# Altium schematic sheet LPG_Weighning_Scale.SchDoc
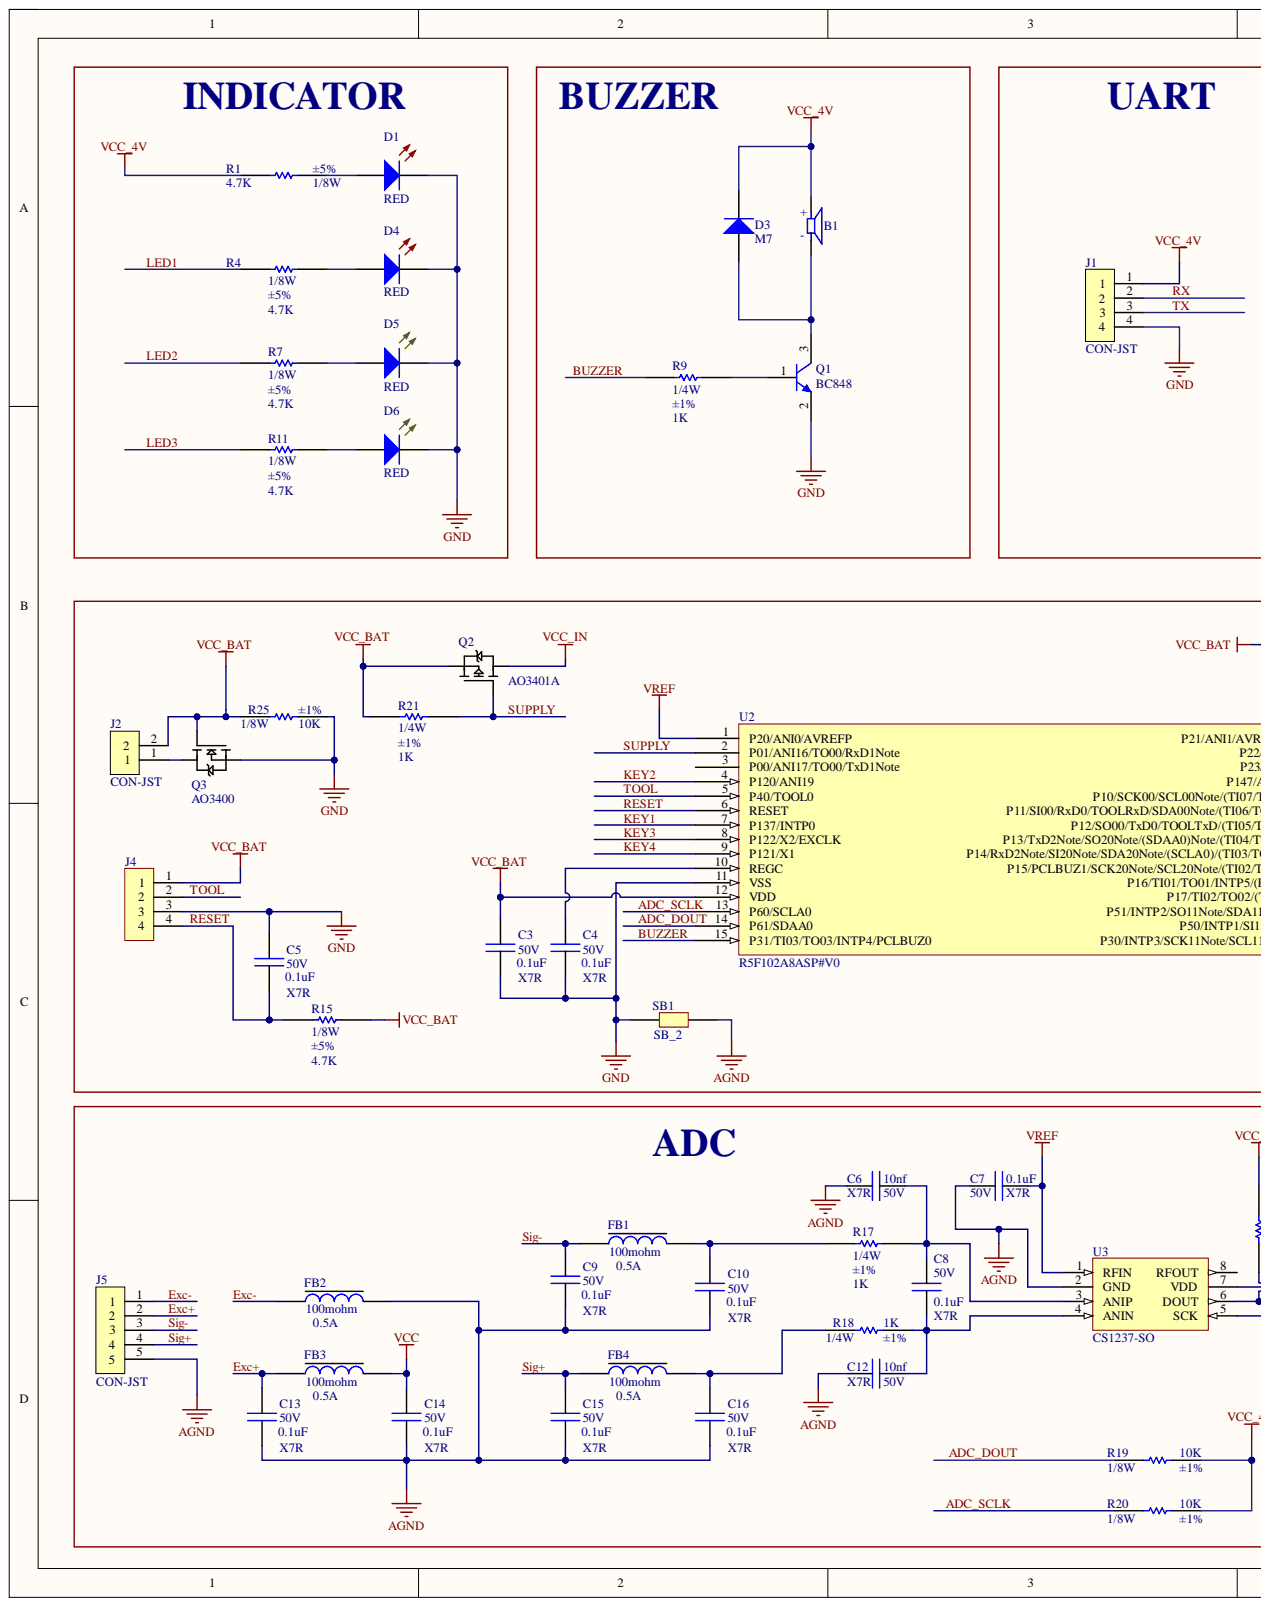
[diagram p0: LPG_Weighning_Scale.SchDoc, left]
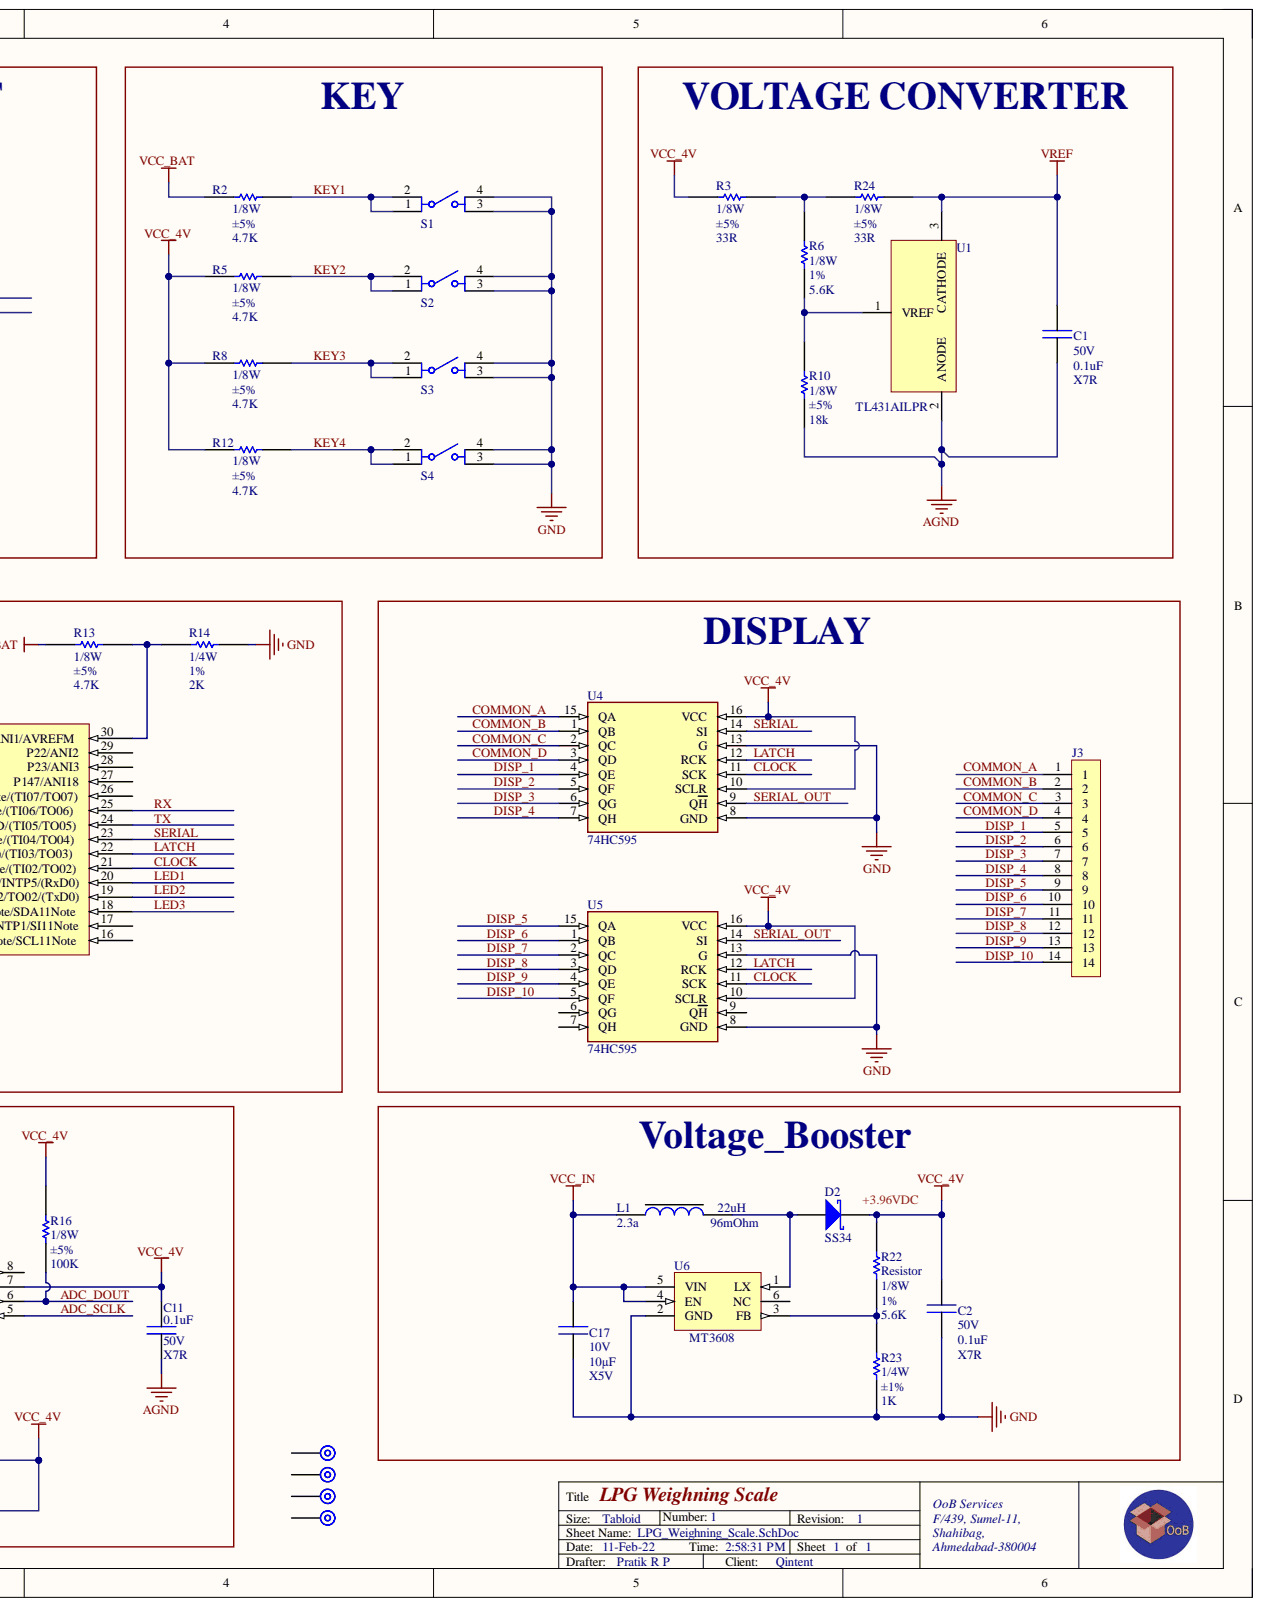
[diagram p1: LPG_Weighning_Scale.SchDoc, right]

## Parts
B1  BUZZER  [Buzzer_PT-1520PQ]  (value not drawn)
C1  value 0.1uF  Dielectric: X7R  Voltage: 50V  [CAPC2012X13_(0805)]  (hidden comment: Capacitor)
C2  value 0.1uF  Dielectric: X7R  Voltage: 50V  [CAPC2012X13_(0805)]  (hidden comment: Capacitor)
C3  value 0.1uF  Dielectric: X7R  Voltage: 50V  [CAPC2012X13_(0805)]  (hidden comment: Capacitor)
C4  value 0.1uF  Dielectric: X7R  Voltage: 50V  [CAPC2012X13_(0805)]  (hidden comment: Capacitor)
C5  value 0.1uF  Dielectric: X7R  Voltage: 50V  [CAPC2012X13_(0805)]  (hidden comment: Capacitor)
C6  value 10nf  Dielectric: X7R  Voltage: 50V  [CAPC2012X13_(0805)]  (hidden comment: Capacitor)
C7  value 0.1uF  Dielectric: X7R  Voltage: 50V  [CAPC2012X13_(0805)]  (hidden comment: Capacitor)
C8  value 0.1uF  Dielectric: X7R  Voltage: 50V  [CAPC2012X13_(0805)]  (hidden comment: Capacitor)
C9  value 0.1uF  Dielectric: X7R  Voltage: 50V  [CAPC2012X13_(0805)]  (hidden comment: Capacitor)
C10  value 0.1uF  Dielectric: X7R  Voltage: 50V  [CAPC2012X13_(0805)]  (hidden comment: Capacitor)
C11  value 0.1uF  Dielectric: X7R  Voltage: 50V  [CAPC2012X13_(0805)]  (hidden comment: Capacitor)
C12  value 10nf  Dielectric: X7R  Voltage: 50V  [CAPC2012X13_(0805)]  (hidden comment: Capacitor)
C13  value 0.1uF  Dielectric: X7R  Voltage: 50V  [CAPC2012X13_(0805)]  (hidden comment: Capacitor)
C14  value 0.1uF  Dielectric: X7R  Voltage: 50V  [CAPC2012X13_(0805)]  (hidden comment: Capacitor)
C15  value 0.1uF  Dielectric: X7R  Voltage: 50V  [CAPC2012X13_(0805)]  (hidden comment: Capacitor)
C16  value 0.1uF  Dielectric: X7R  Voltage: 50V  [CAPC2012X13_(0805)]  (hidden comment: Capacitor)
C17  value 10µF  Dielectric: X5V  Voltage: 10V  [CAPC2012X13_(0805)]  (hidden comment: Capacitor)
D1  RED  [Würth Elektronik_151031SS06000_LED3MM_ROUND]
D2  SS34  [SMA]
D3  M7  [SODFL530X110-2N]
D4  RED  [Würth Elektronik_151031SS06000_LED3MM_ROUND]
D5  RED  [Würth Elektronik_151031SS06000_LED3MM_ROUND]
D6  RED  [Würth Elektronik_151031SS06000_LED3MM_ROUND]
FB1  Current Rating: 0.5A  Resistance: 100mohm  [IND1680X70_(0603)]  (hidden comment: Ferrite Bead)
FB2  Current Rating: 0.5A  Resistance: 100mohm  [IND1680X70_(0603)]  (hidden comment: Ferrite Bead)
FB3  Current Rating: 0.5A  Resistance: 100mohm  [IND1680X70_(0603)]  (hidden comment: Ferrite Bead)
FB4  Current Rating: 0.5A  Resistance: 100mohm  [IND1680X70_(0603)]  (hidden comment: Ferrite Bead)
J1  CON-JST  [JST_S_4]
J2  CON-JST  [JST_S_2]
J3  CON-MH-2.54mm-14x1-Segment Display-Wurth  [MH_2.54mm_14_Dispay]  (value not drawn)
J4  61300411121  [MH_2.54mm_4_WURTH_61300411121]  (value not drawn)
J5  CON-JST  [JST_S_5]
L1  value 22uH  Amp: 2.3a  Resistor: 96mOhm  [SRN6045TA]  (hidden comment: SRR1208-120ML)
MH1  MT-d3_D3mm_5mmcopper-Mounting Hole-OOB_1  [MOUNTING_HOLE_3_5mm coper]  (value not drawn)
MH2  MT-d3_D3mm_5mmcopper-Mounting Hole-OOB_1  [MOUNTING_HOLE_3_5mm coper]  (value not drawn)
MH3  MT-d3_D3mm_5mmcopper-Mounting Hole-OOB_1  [MOUNTING_HOLE_3_5mm coper]  (value not drawn)
MH4  MT-d3_D3mm_5mmcopper-Mounting Hole-OOB_1  [MOUNTING_HOLE_3_5mm coper]  (value not drawn)
Q1  BC848  [SOT95P230X110-3N_(SOT23-3)]
Q2  MFG PN: AO3401A  [SOT95P230X110-3N_(SOT23-3)]  (hidden comment: Mosfet)
Q3  AO3400  [SOT95P230X110-3N_(SOT23-3)]
R1  Resistor  value 4.7K  Tolerance: ±5%  Wattage: 1/8W  [RESC2113X06N_(0805)]
R2  Resistor  value 4.7K  Tolerance: ±5%  Wattage: 1/8W  [RESC2113X06N_(0805)]
R3  Resistor  value 33R  Tolerance: ±5%  Wattage: 1/8W  [RESC2113X06N_(0805)]
R4  Resistor  value 4.7K  Tolerance: ±5%  Wattage: 1/8W  [RESC2113X06N_(0805)]
R5  Resistor  value 4.7K  Tolerance: ±5%  Wattage: 1/8W  [RESC2113X06N_(0805)]
R6  Resistor  value 5.6K  Tolerance: 1%  Wattage: 1/8W  [RESC2113X06N_(0805)]
R7  Resistor  value 4.7K  Tolerance: ±5%  Wattage: 1/8W  [RESC2113X06N_(0805)]
R8  Resistor  value 4.7K  Tolerance: ±5%  Wattage: 1/8W  [RESC2113X06N_(0805)]
R9  Resistor  value 1K  Tolerance: ±1%  Wattage: 1/4W  [RESC2113X06N_(0805)]
R10  Resistor  value 18k  Tolerance: ±5%  Wattage: 1/8W  [RESC2113X06N_(0805)]
R11  Resistor  value 4.7K  Tolerance: ±5%  Wattage: 1/8W  [RESC2113X06N_(0805)]
R12  Resistor  value 4.7K  Tolerance: ±5%  Wattage: 1/8W  [RESC2113X06N_(0805)]
R13  Resistor  value 4.7K  Tolerance: ±5%  Wattage: 1/8W  [RESC2113X06N_(0805)]
R14  Resistor  value 2K  Tolerance: 1%  Wattage: 1/4W  [RESC2113X06N_(0805)]
R15  Resistor  value 4.7K  Tolerance: ±5%  Wattage: 1/8W  [RESC2113X06N_(0805)]
R16  Resistor  value 100K  Tolerance: ±5%  Wattage: 1/8W  [RESC2113X06N_(0805)]
R17  Resistor  value 1K  Tolerance: ±1%  Wattage: 1/4W  [RESC2113X06N_(0805)]
R18  Resistor  value 1K  Tolerance: ±1%  Wattage: 1/4W  [RESC2113X06N_(0805)]
R19  Resistor  value 10K  Tolerance: ±1%  Wattage: 1/8W  [RESC2113X06N_(0805)]
R20  Resistor  value 10K  Tolerance: ±1%  Wattage: 1/8W  [RESC2113X06N_(0805)]
R21  Resistor  value 1K  Tolerance: ±1%  Wattage: 1/4W  [RESC2113X06N_(0805)]
R22  Resistor  value 5.6K  Tolerance: 1%  Wattage: 1/8W  [RESC2113X06N_(0805)]
R23  Resistor  value 1K  Tolerance: ±1%  Wattage: 1/4W  [RESC2113X06N_(0805)]
R24  Resistor  value 33R  Wattage: 1/8W  [RESC2113X06N_(0805)]
R25  Resistor  value 10K  Tolerance: ±1%  Wattage: 1/8W  [RESC2113X06N_(0805)]
S1  Switch  [DTE6]  (value not drawn)
S2  Switch  [DTE6]  (value not drawn)
S3  Switch  [DTE6]  (value not drawn)
S4  Switch  [DTE6]  (value not drawn)
SB1  SB_2  [SB_2]  (no BOM)
U1  TL431AILPR  [TO-92]
U2  R5F102A8ASP#V0  [TSOP65P810X130-30N]
U3  CS1237-SO  [SOIC127P600X175-8N]
U4  74HC595  [SOIC127P600X175-16N]
U5  74HC595  [SOIC127P600X175-16N]
U6  MFG PN: MT3608  [SOT23-6]  (hidden comment: IC-VREG-SOT23-6-AEROSEMI)

## Nets
AGND: C1.1 C6.2 C7.1 C9.2 C10.2 C11.1 C12.2 C13.2 C14.2 C15.2 C16.2 FB2.2 J5.5 R10.1 SB1.2 U1.2 U3.2(GND)
GND: C2.2 C3.2 C4.2 C5.1 C17.2 D1.C D4.C D5.C D6.C J1.4 J4.3 Q1.2 Q3.2 R14.1 R23.1 R25.2 S1.3 S1.4 S2.3 S2.4 S3.3 S3.4 S4.3 S4.4 SB1.1 U2.11(VSS) U4.8(GND) U4.13(G) U5.8(GND) U5.13(G) U6.2(GND)
VCC: C14.1 FB3.2
VCC_4V: B1.1 C2.1 C11.2 D2.2 D3.C J1.1 R1.1 R3.1 R5.1 R8.1 R12.1 R16.2 R19.1 R20.1 R22.2 U3.7(VDD) U4.10(SCLR) U4.16(VCC) U5.10(SCLR) U5.16(VCC)
VCC_BAT: C3.1 J2.2 J4.1 Q2.2 Q3.1 R2.1 R13.1 R15.2 R21.1 R25.1 U2.12(VDD)
VCC_IN: C17.1 L1.1 Q2.3 U6.4(EN) U6.5(VIN)
VREF: C1.2 C7.2 R24.2 U1.3 U2.1(P20/ANI0/AVREFP) U3.1(RFIN)
ADC_DOUT: R16.1 R19.2 U2.14(P61/SDAA0) U3.6(DOUT)
ADC_SCLK: R20.2 U2.13(P60/SCLA0) U3.5(SCK)
BUZZER: R9.1 U2.15(P31/TI03/TO03/INTP4/PCLBUZ0)
CLOCK: U2.21(P15/PCLBUZ1/SCK20Note/SCL20Note/(TI02/TO02)) U4.11(SCK) U5.11(SCK)
COMMON_A: J3.1 U4.15(QA)
COMMON_B: J3.2 U4.1(QB)
COMMON_C: J3.3 U4.2(QC)
COMMON_D: J3.4 U4.3(QD)
DISP_1: J3.5 U4.4(QE)
DISP_2: J3.6 U4.5(QF)
DISP_3: J3.7 U4.6(QG)
DISP_4: J3.8 U4.7(QH)
DISP_5: J3.9 U5.15(QA)
DISP_6: J3.10 U5.1(QB)
DISP_7: J3.11 U5.2(QC)
DISP_8: J3.12 U5.3(QD)
DISP_9: J3.13 U5.4(QE)
DISP_10: J3.14 U5.5(QF)
Exc+: C13.1 FB3.1 J5.2
Exc-: FB2.1 J5.1
KEY1: R2.2 S1.1 S1.2 U2.7(P137/INTP0)
KEY2: R5.2 S2.1 S2.2 U2.4(P120/ANI19)
KEY3: R8.2 S3.1 S3.2 U2.8(P122/X2/EXCLK)
KEY4: R12.2 S4.1 S4.2 U2.9(P121/X1)
LATCH: U2.22(P14/RxD2Note/SI20Note/SDA20Note/(SCLA0)/(TI03/TO03)) U4.12(RCK) U5.12(RCK)
LED1: R4.1 U2.20(P16/TI01/TO01/INTP5/(RxD0))
LED2: R7.1 U2.19(P17/TI02/TO02/(TxD0))
LED3: R11.1 U2.18(P51/INTP2/SO11Note/SDA11Note)
RESET: C5.2 J4.4 R15.1 U2.6(RESET)
RX: J1.2 U2.25(P11/SI00/RxD0/TOOLRxD/SDA00Note/(TI06/TO06))
SERIAL: U2.23(P13/TxD2Note/SO20Note/(SDAA0)Note/(TI04/TO04)) U4.14(SI)
SERIAL_OUT: U4.9(QH) U5.14(SI)
Sig+: C15.1 FB4.1 J5.4
Sig-: C9.1 FB1.1 J5.3
SUPPLY: Q2.1 R21.2 U2.2(P01/ANI16/TO00/RxD1Note)
TOOL: J4.2 U2.5(P40/TOOL0)
TX: J1.3 U2.24(P12/SO00/TxD0/TOOLTxD/(TI05/TO05))
NetB1_2: B1.2 D3.A Q1.3
NetC4_1: C4.1 U2.10(REGC)
NetC6_1: C6.1 C8.2 R17.2 U3.3(ANIP)
NetC8_1: C8.1 C12.1 R18.2 U3.4(ANIN)
NetC10_1: C10.1 FB1.2 R17.1
NetC16_1: C16.1 FB4.2 R18.1
NetD1_A: D1.A R1.2
NetD2_1: D2.1 L1.2 U6.1(LX)
NetD4_A: D4.A R4.2
NetD5_A: D5.A R7.2
NetD6_A: D6.A R11.2
NetJ2_1: J2.1 Q3.3
NetQ1_1: Q1.1 R9.2
NetR3_2: R3.2 R6.2 R24.1
NetR6_1: R6.1 R10.2 U1.1
NetR13_2: R13.2 R14.2 U2.30(P21/ANI1/AVREFM)
NetR22_1: R22.1 R23.2 U6.3(FB)

Not connected: MH1 MT; MH2 MT; MH3 MT; MH4 MT; U2 3(P00/ANI17/TO00/TxD1Note) 16(P30/INTP3/SCK11Note/SCL11Note) 17(P50/INTP1/SI11Note) 26(P10/SCK00/SCL00Note/(TI07/TO07)) 27(P147/ANI18) 28(P23/ANI3) 29(P22/ANI2); U3 8(RFOUT); U5 6(QG) 7(QH) 9(QH); U6 6(NC)

## Library data of the parts
B1 = BZ-PIEZO-TRANSDUCER-1-25V-TH-Mallory Sonalert Products Inc.: AUDIO PIEZO TRANSDUCER 1-25V TH; Lib Standard: 1.0; MFG PN: PT-1520PQ; Owner: OoB Services; Component Kind: Standard; Creation Date: 16-08-2021; Library Name: OoB_Integrated_Lib.IntLib; MFG: Mallory Sonalert Products Inc.; Engineer Created: SL
C1-C5 C7-C11 C13-C16 = CAP-0805-0.1uF-50V-X7R-CL-Samsung: CAP CER 0.1uF 50V X7R 0805; Component Status: Active; Lib Standard: 1.0; MFG: Samsung Electro-Mechanics; MFG PN: CL21B104KBCNNNC; MFG Series: CL; Owner: OoB Services; QA Status: Created; Component Kind: Standard; Creation Date: 11/1/2021; Library Name: OoB_Integrated_Lib.IntLib; Engineer Created: AC
C6 C12 = CAP-0805-10nf-50-C0G-1%-: CAP CER 0805 10NF 50V C0G 1%; Component Status: Active; Lib Standard: 1.0; MFG: KEMET; MFG PN: C0805C103F5GECAUTO; Owner: OoB Services; QA Status: Created; Component Kind: Standard; Creation Date: 17/05/2021; Library Name: OoB_Integrated_Lib.IntLib; Engineer Created: SL
C17 = CAP-0805-10µF-10V-X5V-YAGEO: CAP CER 10UF 10V Y5V 0805; Component Status: Active; Lib Standard: 1.0; MFG: YAGEO; MFG PN: CC0805ZKY5V6BB106; MFG Series: CL; Owner: OoB Services; QA Status: Created; Component Kind: Standard; Creation Date: 19/08/2021; Library Name: OoB_Integrated_Lib.IntLib; Engineer Created: SL
D1 D4 = D-LED-TH3MM-Würth Elektronik-WL-TMRC-RED: LED RED DIFFUSED 3MM ROUND T/H; Component Status: Active; Forward current: 20mA; Forward Voltage: 2.2V; Lib Standard: 1.0; MFG PN: 151031SS06000; Owner: OoB Services; QA Status: Created; Component Kind: Standard; Creation Date: 29/1/2021; Library Name: OoB_Integrated_Lib.IntLib; MFG: Würth Elektronik; MFG Series: WL-TMRC; Engineer Created: AC
D2 = D-30V-1A-SOD123-ON Semiconductor-SS34: DIODE SCHOTTKY 30V 500MA SOD123; Component Status: Active; Lib Standard: 1.0; MFG Series: D-FLAT; Owner: OoB Services; QA Status: Created; Component Kind: Standard; Creation Date: 10/03/2021; Forward current: 500MA; Library Name: OoB_Integrated_Lib.IntLib; MFG: ON Semiconductor; MFG PN: SS34; Reverse Voltage: 30V; Engineer Created: SL
D3 = D-STD-SMA-1000V-1A-Diodes Incorporated: DIODE STD SMA 1000V 1A; Component Status: Active; Forward Voltage: 1.7V; Lib Standard: 1.0; MFG Series: D-FLAT; Owner: OoB Services; QA Status: Created; Component Kind: Standard; Creation Date: 1/2/2021; Forward current: 1A; Library Name: OoB_Integrated_Lib.IntLib; MFG: Diodes Incorporated; MFG PN: M7; Reverse Voltage: 1000V; Engineer Created: AC
D5 D6 = D-LED-TH3MM-Kingbright-GREEN: LED GREEN DIFFUSED T-1 T/H; Component Status: Active; Forward current: 20mA; Forward Voltage: 2.2V; Lib Standard: 1.0; MFG PN: WP3A10GD; Owner: OoB Services; QA Status: Created; Component Kind: Standard; Creation Date: 6/8/21; Library Name: OoB_Integrated_Lib.IntLib; MFG: Kingbright; Engineer Created: AC
FB1-FB4 = FER-5A-100mohm-0603-Laird-Signal Integrity Products: FERRITE BEAD 47 OHM 0603 1LN; Component Status: Active; Impedance@100MHz: 47ohms; Lib Standard: 1.0; MFG PN: LI0603E470R-10; Owner: OoB Services; QA Status: Created; Component Kind: Standard; Creation Date: 14/08/2021; Library Name: OoB_Integrated_Lib.IntLib; MFG: Laird-Signal Integrity Products; Engineer Created: SL
J1 = CON-JST-2.5mm-4-XH-JST_Sales_America: CONN JST HEADER VERT 4POS 2.5MM; Component Status: In Dev/QA; Current Capacity: 3A; Lib Standard: 1.0; MFG: JST Sales America Inc.; MFG PN: B4B-XH-AM(LF)(SN); MFG Series: XH; Number of Positions: 4; Owner: OoB Sevices; QA Status: Created; Component Kind: Standard; Creation Date: 19/12/2020; Library Name: OoB_Integrated_Lib.IntLib; Number of Rows: 1; Pitch: 2.5mm; Engineer Created: PS
J2 = CON-JST-2.5mm-2-XH-JST_Sales_America: CONN JST HEADER VERT 2POS 2.5MM; Component Status: In Dev/QA; Current Capacity: 3A; Lib Standard: 1.0; MFG: JST Sales America Inc.; MFG PN: B2B-XH-AM(LF)(SN); MFG Series: XH; Number of Positions: 2; Owner: Oxit LLC; QA Status: Created; Component Kind: Standard; Creation Date: 19/12/2020; Library Name: OoB_Integrated_Lib.IntLib; Number of Rows: 1; Pitch: 2.5mm; Engineer Created: PS
J3 = CON-MH-2.54mm-14x1-Segment Display-Wurth: CONN HEADER VERT 14POS 2.54MM; Component Status: Active; Current Capacity: 3A; Lib Standard: 1.0; MFG: Würth Elektronik; MFG PN: 61301411121; Number of Positions: 14; Owner: OoB Services; QA Status: Created; Component Kind: Standard; Creation Date: 25/08/2021; Library Name: OoB_Integrated_Lib.IntLib; MFG Series: -; Number of Rows: 1; Pitch: 2.54mm; Engineer Created: SL
J4 = CON-MH-2.54mm-4x1-Wurth: CONN HEADER VERT 4POS 2.54MM; Component Status: Active; Current Capacity: 3A; Lib Standard: 1.0; MFG: Würth Elektronik; Number of Positions: 4; Owner: OoB Services; QA Status: Created; Component Kind: Standard; Creation Date: 22/12/2020; Library Name: OoB_Integrated_Lib.IntLib; MFG PN: 61300411121; MFG Series: -; Number of Rows: 1; Pitch: 2.54mm; Engineer Created: PP
J5 = CON-JST-2.5mm-5-XH-JST_Sales_America: CONN JST HEADER VERT 5POS 2.5MM; Component Status: In Dev/QA; Current Capacity: 3A; Lib Standard: 1.0; MFG: JST Sales America Inc.; MFG PN: B5B-XH-AM(LF)(SN); Number of Positions: 5; Owner: OoB Services; QA Status: Created; Component Kind: Standard; Library Name: OoB_Integrated_Lib.IntLib; Number of Rows: 1; Pitch: 2.5mm; Engineer Created: PS
L1 = IND-22UH-2.3A 96 MOHM-Bourns Inc.: FIXED IND 22UH 2.3A 96 MOHM SMD; Component Status: In Dev/QA; Lib Standard: 1.0; MFG PN: SRN6045TA-220M; Owner: OoB Services; QA Status: Created; Component Kind: Standard; Library Name: OoB_Integrated_Lib.IntLib; MFG: Bourns Inc.; Engineer Created: SL
MH1-MH4 = MT-d3_D3mm_5mmcopper-Mounting Hole-OOB_1: MOUNTING HOLE; Component Kind: Standard; Library Name: ELLECO_LIB.IntLib
Q1 = T-NPN-30V-100mA-SOT23-3-BC848BLT3G-ON Semiconductor: TRANS NPN 30V 100MA SOT23-3; Component Status: In Dev/QA; Lib Standard: 1.0; MFG: 2; Owner: OoB Services; QA Status: Created; Component Kind: Standard; Creation Date: 15/03/2021; Current: 100mA; Library Name: OoB_Integrated_Lib.IntLib; MFG PN: BC848BLT3G; MFG Series: -; Voltage: 30V; Engineer Created: SL
Q2 = T-Pch-30V-4A-SOT23-Alpha_Omega: MOSFET P-CH 30V 4A SOT23; Component Number: CMP00089; Component Status: In Dev/QA; Lib Standard: 1.0; MFG: Alpha & Omega Semiconductor Inc.; Owner: OoB Services; QA Status: Created; Component Kind: Standard; Current: 4A; Library Name: OoB_Integrated_Lib.IntLib; MFG Series: -; Voltage: 30V; Engineer Created: PS
Q3 = T- N-CH 30V 5.8A SOT23-3-AO3400-Alpha & Omega Semiconductor Inc.: MOSFET N-CH 30V 5.8A SOT23-3; Component Status: In Dev/QA; Current: 500mA; Lib Standard: 1.0; Owner: OoB Services; QA Status: Created; Voltage: 45V; Component Kind: Standard; MFG: Alpha & Omega Semiconductor Inc.; MFG PN: AO3400; MFG Series: -; Engineer Created: PP; Library Name: Schematic_Symbole_Lib.SchLib
R1 R2 R4 R5 R7 R8 R11-R13 R15 = RES-0805-4.7K-1/8W-±1%-RMCF-RC0805JR-074K7L-Stackpole Electronics Inc: RES SMD 4.7K OHM 5% 1/8W 0805; Component Status: Active; Lib Standard: 1.0; MFG Series: RMCF; Owner: OoB Services; QA Status: Created; Component Kind: Standard; Creation Date: 15/03/2021; Library Name: OoB_Integrated_Lib.IntLib; MFG: Stackpole Electronics Inc; MFG PN: RC0805JR-074K7L; Engineer Created: SL
R3 R24 = RES-0805-33R-1/8W-±5%-RC_L-Yageo_1: RES 33R OHM 5% 1/8W 0805; Component Status: Active; Lib Standard: 1.0; MFG: Yageo; MFG PN: RC0805JR-0733RL; MFG Series: RC_L; Owner: OoB Services; QA Status: Created; Component Kind: Standard; Creation Date: 23/06/2021; Library Name: OoB_Integrated_Lib.IntLib; Engineer Created: SL
  R24: Tolerance: ±5%; Value: 33R
R6 R22 = RES-0805-5.6K-1/8W-1%-RMCF-Stackpole Ele: RES 5.6K OHM 1% 1/4W 0805; Component Status: Active; Lib Standard: 1.0; MFG: Stackpole Electronics Inc; MFG PN: RMCF0805FT5K60; MFG Series: RMCF; Owner: OoB Services; QA Status: Created; Component Kind: Standard; Creation Date: 21/12/2020; Library Name: OoB_Integrated_Lib.IntLib; Engineer Created: PP
R9 R17 R18 R21 R23 = RES-0805-1K-1/4W-±1%-RNCP-Stackpole: RES 1K OHM 1% 1/4W 0805; Component Status: Active; Lib Standard: 1.0; MFG: Stackpole Electronics Inc; MFG PN: RNCP0805FTD1K00; MFG Series: RNCP; Owner: OoB Services; QA Status: Created; Component Kind: Standard; Creation Date: 11/1/2021; Library Name: OoB_Integrated_Lib.IntLib; Engineer Created: AC
R10 = RES-0805-18K-1/8W-±5%-RC-Yageo: RES SMD 18K OHM 5% 1/8W 0805; Component Status: Active; Lib Standard: 1.0; MFG: Yageo; MFG PN: RC0805JR-0718KL; MFG Series: RC; Owner: OoB Services; QA Status: Created; Component Kind: Standard; Creation Date: 16/08/2021; Library Name: OoB_Integrated_Lib.IntLib; Engineer Created: SL
R14 = RES-0805-2K-1/4W-1%-RNCP-Stackpole Ele: RES 2K OHM 1% 1/4W 0805; Component Status: Active; Lib Standard: 1.0; MFG: Stackpole Electronics Inc; MFG PN: RNCP0805FTD2K00; MFG Series: RNCP; Owner: OoB Services; QA Status: Created; Component Kind: Standard; Creation Date: 21/12/2020; Library Name: OoB_Integrated_Lib.IntLib; Engineer Created: PP
R16 = RES-0805-100K-1/8W-±5%-RMCF-Stackpole: RES 100K OHM 5% 1/8W 0805; Component Status: Active; Lib Standard: 1.0; MFG: Stackpole Electronics Inc; MFG PN: RMCF0805JT100K; MFG Series: RMCF; Owner: OoB Services; QA Status: Created; Component Kind: Standard; Creation Date: 11/1/2021; Library Name: OoB_Integrated_Lib.IntLib; Engineer Created: AC
R19 R20 R25 = RES-0805-10K-1/8W-±1%-RC0805FR-0710KL-Yageo: RES 10K OHM 1% 1/8W 0805; Component Status: Active; Lib Standard: 1.0; MFG: Yageo; Owner: OoB Services; QA Status: Created; Component Kind: Standard; Creation Date: 10/03/2021; Library Name: OoB_Integrated_Lib.IntLib; MFG PN: RC0805FR-0710KL; Engineer Created: SL
S1-S4 = SW-SPST-DTE_6_Generic: DTE-6 tactile switch; Component Number: CMP00138; Component Status: In Dev/QA; Lib Standard: 1.0; MFG: GENRIC; MFG PN: DTE_6; MFG Series: NA; Owner: OoB Services; QA Status: Created; Component Kind: Standard; Creation Date: 3/11/2020; Library Name: OoB_Integrated_Lib.IntLib; Engineer Created: PP
U1 = IC -VREF-SHUNT-ADJ-1%- TO92-3-Texas Instruments: IC VREF SHUNT ADJ 1% TO92-3; Component Status: In Dev/QA; Lib Standard: 1.0; Owner: OoB Services; QA Status: Created; Component Kind: Standard; Creation Date: 16/08/2021; Library Name: OoB_Integrated_Lib.IntLib; MFG: Texas Instruments; MFG PN: TL431AILPR; Engineer Created: SL
U2 = IC-MCU-16BI- 8KB-30LSSOP-Renesas Electronics America Inc: IC MCU 16BIT 8KB FLASH 30LSSOP; Component Status: In Dev/QA; Lib Standard: 1.0; MFG Series: STM8S; Owner: OoB Services; QA Status: Created; Component Kind: Standard; Library Name: OoB_Integrated_Lib.IntLib; MFG: Renesas Electronics America Inc; MFG PN: R5F102A8ASP#V0; Engineer Created: SL
U3 = IC-ADC-CHIPSEA-SOP8_150mil-24BIT: 24 1 Differential SOP-8_150mil Analog To Digital Converters (ADCs) RoHS; Component Status: In Dev/QA; Lib Standard: 1.0; Owner: OoB Services; QA Status: Created; Component Kind: Standard; Creation Date: 14/08/2021; Library Name: OoB_Integrated_Lib.IntLib; MFG: CHIPSEA; MFG PN: CS1237-SO; Engineer Created: AC
U4 U5 = IC-SR-74HC595-8BIT-16SO-STMicroelectronics: FILTER RC(PI) 40 OHM/12PF SMD; Component Status: In Dev/QA; Lib Standard: 1.0; MFG PN: M74HC595YRM13TR; Owner: OoB Services; QA Status: Created; Component Kind: Standard; Creation Date: 25/08/2021; Library Name: OoB_Integrated_Lib.IntLib; MFG: STMicroelectronics; Engineer Created: SL
U6 = IC-VREG-SOT23-6-AEROSEMI: TVS DIODE 5.25V 17V SOT23-6; Component Status: In Dev/QA; Lib Standard: 1.0; QA Status: Created; Component Kind: Standard; Creation Date: 19/08/2021; Library Name: OoB_Integrated_Lib.IntLib; MFG: AEROSEMI; Engineer Created: SL
SB1 = SB_2: Library Name: OoB_Integrated_Lib.IntLib
